# Altium schematic sheet Node Controller v2.SchDoc
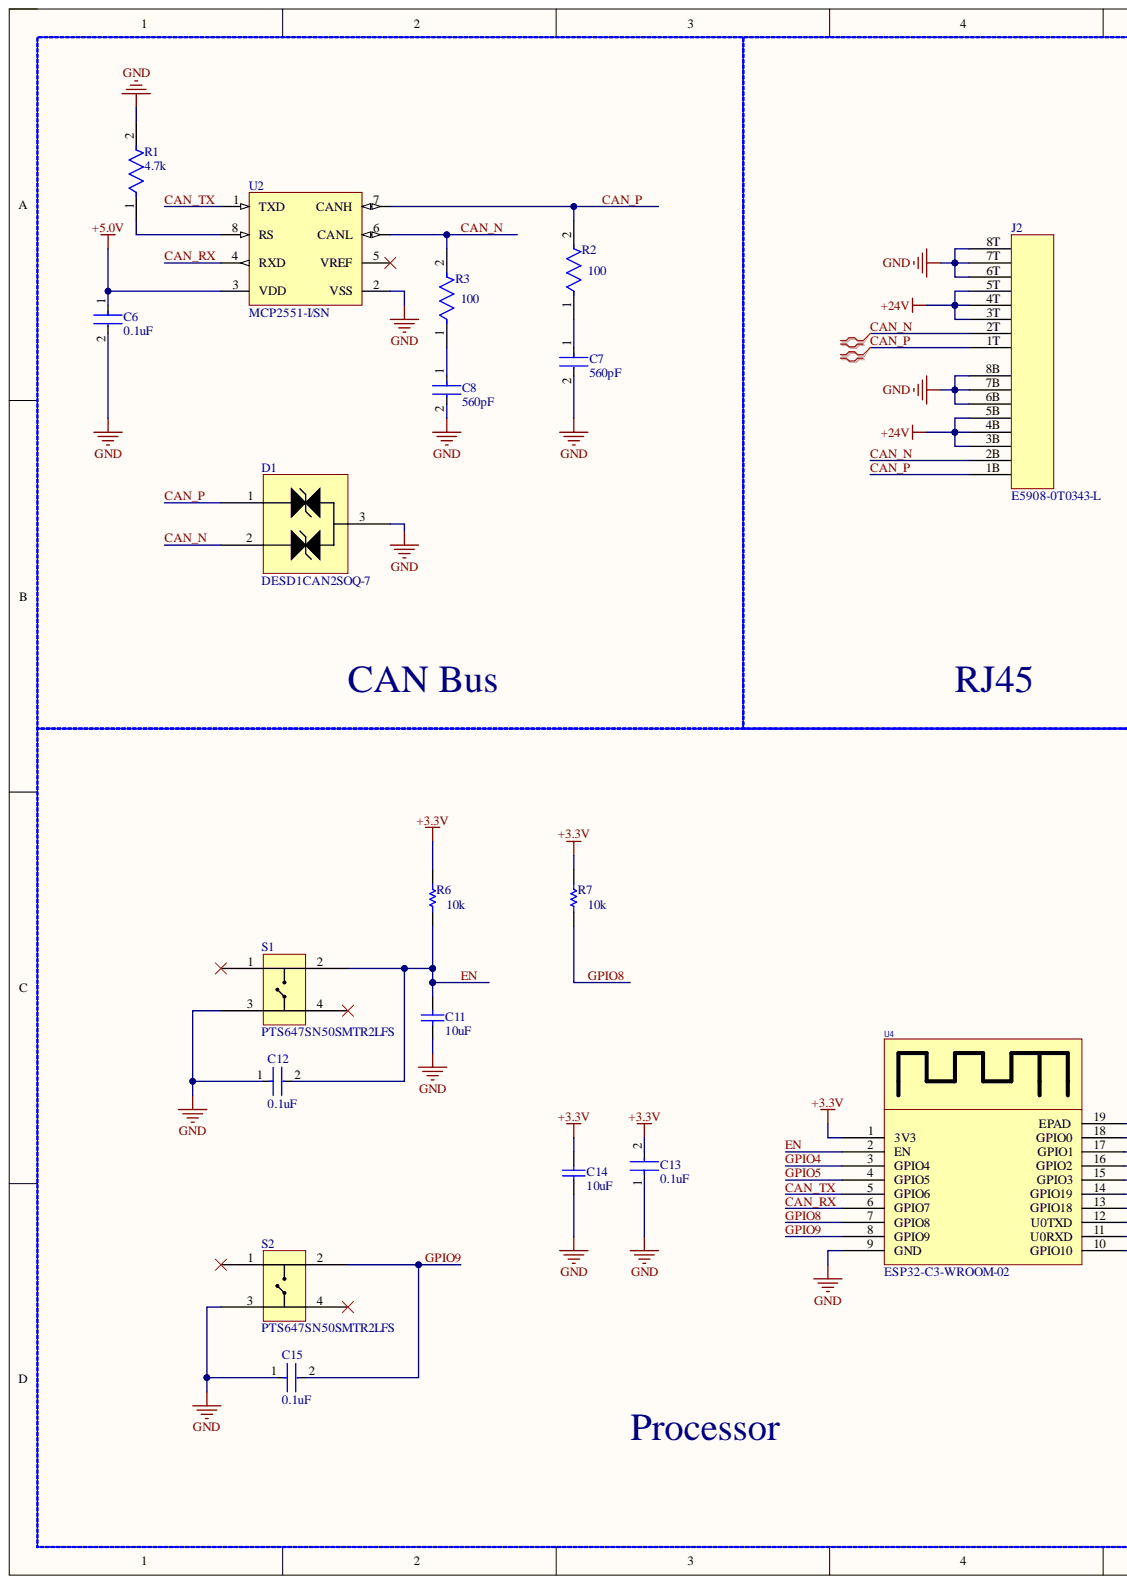
[diagram p0: Node Controller v2.SchDoc, left]
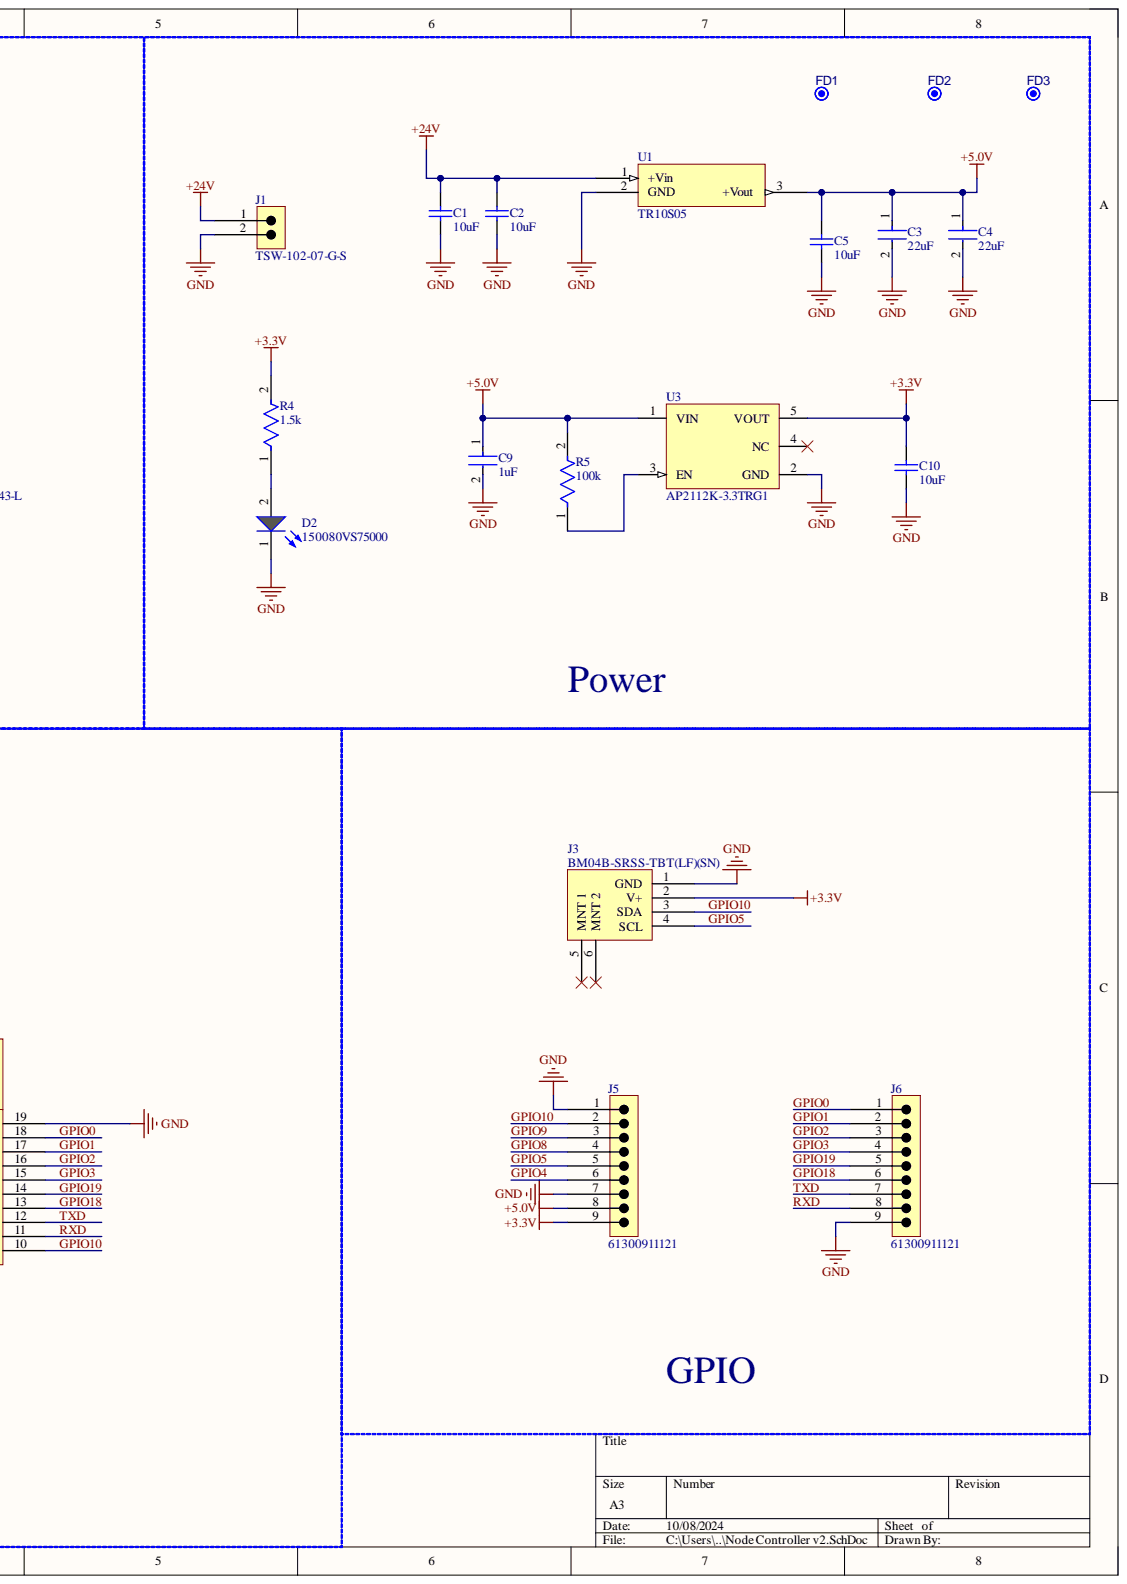
[diagram p1: Node Controller v2.SchDoc, right]

## Parts
C1  10uF  [CAPC2013X145X50ML20T25]
C2  10uF  [CAPC2013X145X50ML20T25]
C3  22uF  [FP-GMC21R-IPC_B]
C4  22uF  [FP-GMC21R-IPC_B]
C5  10uF  [CAPC2013X145X50ML20T25]
C6  0.1uF  [FP-CC0603-DA-IPC_A]
C7  560pF  [FP-CC0805-0_85-IPC_A]
C8  560pF  [FP-CC0805-0_85-IPC_A]
C9  1uF  [FP-CL21-IPC_A]
C10  10uF  [CAPC2013X145X50ML20T25]
C11  10uF  [CAPC2013X145X50ML20T25]
C12  0.1uF  [FP-CC0603-DA-IPC_A]
C13  0.1uF  [FP-CC0603-DA-IPC_A]
C14  10uF  [CAPC2013X145X50ML20T25]
C15  0.1uF  [FP-CC0603-DA-IPC_A]
D1  DESD1CAN2SOQ-7  [SOT95P245X110-3N]
D2  150080VS75000  [WL-SMCW_0805_150080xx75000]
FD1  JLC FID1X2R  [FID1X2R]  (value not drawn)  (no BOM)
FD2  JLC FID1X2R  [FID1X2R]  (value not drawn)  (no BOM)
FD3  JLC FID1X2R  [FID1X2R]  (value not drawn)  (no BOM)
J1  TSW-102-07-G-S  [FP-TSW-102-07-G-S-MFG]
J2  E5908-0T0343-L  [E5908-0T0343-L-Footprint-1]
J3  BM04B-SRSS-TBT(LF)(SN)  [FP-BM04B-SRSS-TBT_LF_SN-MFG]
J5  61300911121  [61300911121]
J6  61300911121  [61300911121]
R1  4.7k  [FP-RT0805-IPC_A]
R2  100  [FP-RT0805-0_6-IPC_A]
R3  100  [FP-RT0805-0_6-IPC_A]
R4  1.5k  [FP-RT0805-IPC_A]
R5  100k  [FP-RT0805-IPC_A]
R6  10k  [RESC2013X60X35ML10T20]
R7  10k  [RESC2013X60X35ML10T20]
S1  PTS647SN50SMTR2LFS  [PTS647SN50SMTR2LFS-Footprint-1]
S2  PTS647SN50SMTR2LFS  [PTS647SN50SMTR2LFS-Footprint-1]
U1  TR10S05  [TR10S05-Footprint-1]
U2  MCP2551-I/SN  [MCHP-SN8_V]
U3  AP2112K-3.3TRG1  [FP-SOT25-IPC_B]
U4  ESP32-C3-WROOM-02  [ESP32-C3-WROOM-02]

## Nets
+3.3V: C10.2 C13.2 C14.2 J3.2(V+) J5.9 R4.2 R6.2 R7.2 U3.5(VOUT) U4.1(3V3)
+5.0V: C3.1 C4.1 C5.2 C6.1 C9.1 J5.8 R5.2 U1.3 U2.3(VDD) U3.1(VIN)
+24V: C1.2 C2.2 J1.1 J2.3B J2.3T J2.4B J2.4T J2.5B J2.5T U1.1
GND: C1.1 C2.1 C3.2 C4.2 C5.1 C6.2 C7.2 C8.2 C9.2 C10.1 C11.1 C12.1 C13.1 C14.1 C15.1 D1.3 D2.1 J1.2 J2.6B J2.6T J2.7B J2.7T J2.8B J2.8T J3.1(GND) J5.1 J5.7 J6.9 R1.2 S1.3 S2.3 U1.2 U2.2(VSS) U3.2(GND) U4.9(GND) U4.19(EPAD)
CAN_N: D1.2 J2.2B J2.2T R3.2 U2.6(CANL)
CAN_P: D1.1 J2.1B J2.1T R2.2 U2.7(CANH)
CAN_RX: U2.4(RXD) U4.6(GPIO7)
CAN_TX: U2.1(TXD) U4.5(GPIO6)
EN: C11.2 C12.2 R6.1 S1.2 U4.2(EN)
GPIO0: J6.1 U4.18(GPIO0)
GPIO1: J6.2 U4.17(GPIO1)
GPIO2: J6.3 U4.16(GPIO2)
GPIO3: J6.4 U4.15(GPIO3)
GPIO4: J5.6 U4.3(GPIO4)
GPIO5: J3.4(SCL) J5.5 U4.4(GPIO5)
GPIO8: J5.4 R7.1 U4.7(GPIO8)
GPIO9: C15.2 J5.3 S2.2 U4.8(GPIO9)
GPIO10: J3.3(SDA) J5.2 U4.10(GPIO10)
GPIO18: J6.6 U4.13(GPIO18)
GPIO19: J6.5 U4.14(GPIO19)
RXD: J6.8 U4.11(U0RXD)
TXD: J6.7 U4.12(U0TXD)
NetC7_1: C7.1 R2.1
NetC8_1: C8.1 R3.1
NetD2_2: D2.2 R4.1
NetR1_1: R1.1 U2.8(RS)
NetR5_1: R5.1 U3.3(EN)

Not connected: J3 5(MNT_1) 6(MNT_2); S1 1 4; S2 1 4; U2 5(VREF); U3 4(NC)

## Library data of the parts
C1 C2 C5 C10 C11 C14 = CMP-00010-1: Ceramic Capacitor, Multilayer, Ceramic, 10V, 80% +Tol, 20% -Tol, Y5V, -82/+22% TC, 10uF, Surface Mount, 0805; Multilayer: Yes; Capacitor Type: Ceramic; Case/Package: 0805; Component Kind: Standard; Dielectric Material: Ceramic; Height: 1.25mm; JESD-609 Code: e3; Length: 2mm; Library Name: C0514760 C0514760; Max Operating Temperature: 85°C; Min Operating Temperature: -30°C; Mounting Technology: Surface Mount; Package Shape: Rectangular; Package Style: SMTMeter; Packaging: Tape and Reel; Pins: 2; Rated DC Voltage (URdc): 10V; Reach SVHC Compliant: Yes; RoHS Compliant: Yes; Temperature Characteristics Code: Y5V; Terminal Finish: Nickel; Termination: Wraparound; Tolerance  (fill in as +-): 20%; Tolerance (fill in as +-): 80%; Value: 10uF; Width: 1.25mm
C3 C4 = CMP-00027-1: Ceramic Capacitor, Multilayer, Ceramic, 35V, 20% +Tol, 20% -Tol, X5R, 15% TC, 22uF, Surface Mount, 0805; Component Kind: Standard; Library Name: C0514760 C0514760
C6 C12 C13 C15 = CMP-00002-1: Ceramic Capacitor, Multilayer, Ceramic, 50V, 10% +Tol, 10% -Tol, X7R, 15% TC, 0.1uF, Surface Mount, 0603; Multilayer: Yes; Value: 100nF; Capacitor Type: Ceramic; Case/Package: 0603; Component Kind: Standard; Dielectric Material: Ceramic; Height: 0.8mm; JESD-609 Code: e3; Length: 1.6mm; Library Name: C0514760 C0514760; Max Operating Temperature: 125°C; Min Operating Temperature: -55°C; Mounting Technology: Surface Mount; Package Shape: Rectangular; Package Style: SMTMeter; Packaging: Tape and Reel; Pins: 2; Rated DC Voltage (URdc): 50V; Reach SVHC Compliant: Yes; RoHS Compliant: Yes; Temperature Characteristics Code: X7R; Terminal Finish: Nickel; Termination: Wraparound; Tolerance  (fill in as +-): 10%; Tolerance (fill in as +-): 10%; Width: 0.8mm
C7 C8 = CMP-00033-1: Ceramic Capacitor, Multilayer, Ceramic, 50V, 10% +Tol, 10% -Tol, X7R, 15% TC, 0.00056uF, Surface Mount, 0805; Multilayer: Yes; Capacitor Type: Ceramic; Case/Package: 0805; Component Kind: Standard; Dielectric Material: Ceramic; JESD-609 Code: e3; Library Name: C0514760 C0514760; Max Operating Temperature: 125°C; Min Operating Temperature: -55°C; Mounting Technology: Surface Mount; Package Shape: Rectangular; Packaging: Tape and Reel; Pins: 2; Rated DC Voltage (URdc): 50V; Reach SVHC Compliant: Yes; RoHS Compliant: Yes; Temperature Characteristics Code: X7R; Terminal Finish: Nickel; Termination: Wraparound; Tolerance  (fill in as +-): 10%; Tolerance (fill in as +-): 10%; Value: 560pF
C9 = CMP-00019-1: Ceramic Capacitor, Multilayer, Ceramic, 50V, 10% +Tol, 10% -Tol, X7R, 15% TC, 1uF, Surface Mount, 0805; Multilayer: Yes; Value: 1µF; Capacitor Type: Ceramic; Case/Package: 0805; Component Kind: Standard; Dielectric Material: Ceramic; Height: 1.35mm; JESD-609 Code: e3; Length: 2mm; Library Name: C0514760 C0514760; Max Operating Temperature: 125°C; Min Operating Temperature: -55°C; Mounting Technology: Surface Mount; Package Shape: Rectangular; Package Style: SMTMeter; Packaging: Tape and Reel; Pins: 2; Rated DC Voltage (URdc): 50V; Reach SVHC Compliant: Yes; RoHS Compliant: Yes; Temperature Characteristics Code: X7R; Terminal Finish: Nickel; Termination: Wraparound; Tolerance  (fill in as +-): 10%; Tolerance (fill in as +-): 10%; Width: 1.25mm
D1 = CMP-00024-2: Trans Voltage Suppressor Diode, 200W, 24V V(RWM), Bidirectional, 2 Element, Silicon; Additional Feature: HIGH RELIABILITY; Max Breakdown Voltage: 30.3V; Max Power Dissipation: 300mW; Min Breakdown Voltage: 25.4V; Number of Elements: 2; Package Body Material: Plastic; Reference Standard: AEC-Q101; Time @ Peak Reflow Temperature-Max (s): 30s; Component Kind: Standard; Diode Element Material: SILICON; Diode Type: TRANS VOLTAGE SUPPRESSOR; JESD-30 Code: R-PDSO-G3; JESD-609 Code: e3; Library Name: C0514760 C0514760; Max Operating Temperature: 150°C; Min Operating Temperature: -65°C; Moisture Sensitivity Level: 1; Non-rep Peak Rev Power Dis-Max: 200W; Package Shape: Rectangular; Package Style: SMALL OUTLINEMeter; Peak Reflow Temperature (Cel): 260°C; Pins: 3; Polarity: Bidirectional; Reach SVHC Compliant: Yes; Rep Pk Reverse Voltage-Max: 24V; RoHS Compliant: Yes; Surface Mount: Yes; Technology: AVALANCHE; Terminal Finish: Tin; Terminal Form: Gull Wing; Terminal Position: DUAL
D2 = CMP-00021-1: Single Color LED, Bright Green, Water Clear, 1.4mm; Channels: 1; Forward Current: 30mA; Luminous Intensity-Nom: 40mcd; Overall Height: 0.7mm; Shape: Rectangular; Viewing Angle: 140°; Color: Green; Component Kind: Standard; Lens Style: WATER CLEAR; Library Name: C0514760 C0514760; Max Operating Temperature: 85°C; Min Operating Temperature: -40°C; Mounting Technology: Surface Mount; Optoelectronic Device Type: SINGLE COLOR LED; Packaging: Tape and Reel; Pins: 2; Reach SVHC Compliant: Yes; RoHS Compliant: Yes; Size: 1.4mm; Terminal Pitch: 2.1mm
FD1-FD3 = CMP-00028-00011-4: Fiducial - Round 1x2mm; Library Name: C0514760 C0514760; Pins: 0
J1 = CMP-00020-2: Board Connector, 2 Contact(s), 1 Row(s), Male, Straight, 0.1 inch Pitch, Solder Terminal, Black Insulator; Additional Feature: E.L.P.; Body/Shell Style: RECEPTACLE; Contact Pattern: RECTANGULAR; Contact Style: SQ PIN-SKT; DIN Conformance: No; Mating Information: MULTIPLE MATING PARTS AVAILABLE; Number Of Connectors: 1; Number of Contacts: 2; Option: General Purpose; Plating Thickness: 10uin; Reference Standard: UL; Reliability: COMMERCIAL; Body Breadth: 0.1inch; Body Depth: 0.1inch; Component Kind: Standard; Connector Type: BOARD CONNECTOR; Contact Finish - Mating: GOLD (10) OVER NICKEL (50); Contact Finish - Termination: Gold (Au) - with Nickel (Ni) barrier; Contact Gender: Male; Contact Material: Bronze; Filter Feature: No; Flammability Rating: UL94 V-0; IEC Conformance: No; Insulation Color: Black; Insulation Material: Glass; JESD-609 Code: e4; Library Name: C0514760 C0514760; Mating Contact Pitch: 0.1inch; MIL Conformance: No; Mixed Contacts: No; Mounting Style: STRAIGHT; Mounting Technology: Board; Number Of PCB Rows: 1; Number of Rows Loaded: 1; PCB Contact Pattern: RECTANGULAR; Reach SVHC Compliant: Yes; RoHS Compliant: Yes; Terminal Length: 0.1inch; Terminal Pitch: 2.54mm; Termination: Solder
J2 = CMP-002-00077-7: Telecom and Datacom Connector, 16 Contact(s), Female, Right Angle, Solder Terminal, Jack; Manufacturer Series: E5908; DIN Conformance: No; Number of Contacts: 16; Option: General Purpose; Body/Shell Style: JACK; Component Kind: Standard; Connector Type: TELECOM AND DATACOM CONNECTOR; Contact Finish - Mating: GOLD (15) OVER NICKEL; Contact Finish - Termination: GOLD (15) OVER NICKEL; Contact Gender: Female; Empty Shell: No; Filter Feature: No; Flammability Rating: UL94 V-0; IEC Conformance: No; Insulation Material: Glass; JESD-609 Code: e4; Library Name: C0514760 C0514760; MIL Conformance: No; Mixed Contacts: No; Mounting Style: RIGHT ANGLE; Mounting Technology: Board; Reach SVHC Compliant: Yes; RoHS Compliant: Yes; Shell Material: Glass; Termination: Solder
J3 = CMP-002-00076-4: 1.0mm Pitch, Ultra-Compact, Low Profile Design, Interchangeable Shrouded Header; Component Kind: Standard; Library Name: C0514760 C0514760
J5 J6 = CMP-00023-4: Board Connector, 9 Contact(s), 1 Row(s), Male, Straight, 0.1 inch Pitch, Solder Terminal, Locking, Black Insulator, Plug; Body Length: 0.9inch; Contact Pattern: RECTANGULAR; Contact Resistance: 20mR; Contact Style: SQ PIN-SKT; Dielectric Withstanding Voltage (AC): 500V; Insulation Resistance: 1GR; Number Of Connectors: 1; Number of Contacts: 9; Rated Current (Signal): 3A; Reference Standard: UL; Reliability: COMMERCIAL; Body Breadth: 0.1inch; Body Depth: 0.1inch; Body/Shell Style: PLUG; Component Kind: Standard; Connector Type: BOARD CONNECTOR; Contact Finish - Mating: GOLD; Contact Finish - Termination: Gold (Au) - with Nickel (Ni) barrier; Contact Gender: Male; Contact Material: Copper Alloy; Insulation Color: Black; Insulation Material: Polybutylene; JESD-609 Code: e4; Library Name: C0514760 C0514760; Mating Contact Pitch: 0.1inch; Max Operating Temperature: 125°C; Min Operating Temperature: -40°C; Mounting Option 1: LOCKING; Mounting Style: STRAIGHT; Mounting Technology: Board; Number of Rows Loaded: 1; PCB Contact Pattern: RECTANGULAR; Reach SVHC Compliant: Yes; RoHS Compliant: Yes; Terminal Length: 0.118inch; Terminal Pitch: 2.54mm; Termination: Solder
R1 = CMP-00015-1: Fixed Resistor, Thin Film, 0.125W, 4700ohm, 150V, 1% +/-Tol, 50ppm/Cel, Surface Mount, 0805; Additional Feature: HIGH PRECISION; Package Height: 0.5mm; Package Length: 2mm; Package Width: 1.25mm; Power: 125mW; Rated Temperature: 70°C; Temperature Coefficient: 50ppm/°C; Value: 4.7kR; Case/Package: 0805; Component Kind: Standard; Construction: Chip; Library Name: C0514760 C0514760; Max Operating Temperature: 155°C; Min Operating Temperature: -55°C; Mounting Technology: Surface Mount; Package Style: SMTMeter; Packaging: Tape and Reel; Pins: 2; Reach SVHC Compliant: Yes; Resistor Type: FIXED RESISTOR; RoHS Compliant: Yes; Technology: THIN FILM; Termination: Wraparound; Tolerance: 1%; Working Voltage: 150V
R2 R3 = CMP-00016-1: Fixed Resistor, Thin Film, 0.125W, 100ohm, 150V, 0.1% +/-Tol, 25ppm/Cel, Surface Mount, 0805; Additional Feature: HIGH PRECISION; Package Height: 0.5mm; Package Length: 2mm; Package Width: 1.25mm; Power: 125mW; Rated Temperature: 70°C; Temperature Coefficient: 25ppm/°C; Value: 100R; Case/Package: 0805; Component Kind: Standard; Construction: Chip; Library Name: C0514760 C0514760; Max Operating Temperature: 155°C; Min Operating Temperature: -55°C; Mounting Technology: Surface Mount; Package Style: SMTMeter; Packaging: Tape and Reel; Pins: 2; Reach SVHC Compliant: Yes; Resistor Type: FIXED RESISTOR; RoHS Compliant: Yes; Technology: THIN FILM; Termination: Wraparound; Tolerance: 0.1%; Working Voltage: 150V
R4 = CMP-00013-1: Fixed Resistor, Thin Film, 0.125W, 1500ohm, 150V, 1% +/-Tol, 50ppm/Cel, Surface Mount, 0805; Additional Feature: HIGH PRECISION; Package Height: 0.5mm; Package Length: 2mm; Package Width: 1.25mm; Power: 125mW; Rated Temperature: 70°C; Temperature Coefficient: 50ppm/°C; Value: 1.5kR; Case/Package: 0805; Component Kind: Standard; Construction: Chip; Library Name: C0514760 C0514760; Max Operating Temperature: 155°C; Min Operating Temperature: -55°C; Mounting Technology: Surface Mount; Package Style: SMTMeter; Packaging: Tape and Reel; Pins: 2; Reach SVHC Compliant: Yes; Resistor Type: FIXED RESISTOR; RoHS Compliant: Yes; Technology: THIN FILM; Termination: Wraparound; Tolerance: 1%; Working Voltage: 150V
R5 = CMP-00014-1: Fixed Resistor, Thin Film, 0.125W, 100000ohm, 150V, 1% +/-Tol, 50ppm/Cel, Surface Mount, 0805; Additional Feature: HIGH PRECISION; Package Height: 0.5mm; Package Length: 2mm; Package Width: 1.25mm; Power: 125mW; Rated Temperature: 70°C; Temperature Coefficient: 50ppm/°C; Value: 100kR; Case/Package: 0805; Component Kind: Standard; Construction: Chip; Library Name: C0514760 C0514760; Max Operating Temperature: 155°C; Min Operating Temperature: -55°C; Mounting Technology: Surface Mount; Package Style: SMTMeter; Packaging: Tape and Reel; Pins: 2; Reach SVHC Compliant: Yes; Resistor Type: FIXED RESISTOR; RoHS Compliant: Yes; Technology: THIN FILM; Termination: Wraparound; Tolerance: 1%; Working Voltage: 150V
R6 R7 = CMP-00012-1: Fixed Resistor, Metal Glaze/thick Film, 0.125W, 10000ohm, 150V, 1% +/-Tol, 100ppm/Cel, Surface Mount, 0805; Additional Feature: LASER TRIMMABLE; Package Height: 0.5mm; Package Length: 2mm; Package Width: 1.25mm; Power: 125mW; Rated Temperature: 70°C; Temperature Coefficient: 100ppm/°C; Value: 10kR; Case/Package: 0805; Component Kind: Standard; Construction: Chip; JESD-609 Code: e3; Library Name: C0514760 C0514760; Max Operating Temperature: 155°C; Min Operating Temperature: -55°C; Mounting Technology: Surface Mount; Package Shape: Rectangular; Package Style: SMTMeter; Packaging: Tape and Reel; Pins: 2; Reach SVHC Compliant: Yes; Resistor Type: FIXED RESISTOR; RoHS Compliant: Yes; Technology: METAL GLAZE/THICK FILM; Terminal Finish: Nickel; Termination: Wraparound; Tolerance: 1%; Working Voltage: 150V
S1 S2 = CMP-011-00013-3: Keypad Switch, 1 Switches, SPST, Momentary-tactile, 0.05A, 12VDC, 1.47N, Solder Terminal, Surface Mount-straight; Component Kind: Standard; Library Name: C0514760 C0514760; RoHS Compliant: Yes
U1 = CMP-019-00050-2: DC-DC Regulated Power Supply Module; Component Kind: Standard; Library Name: C0514760 C0514760; Reach SVHC Compliant: Yes; RoHS Compliant: Yes; Throw Configuration: DC-DC REGULATED POWER SUPPLY MODULE
U2 = CMP-015-00008-2: CAN Transceiver, 1-Trnsvr, PDSO8; Channels: 1; Data Rate: 1000Mbps; Max Supply Current: 75uA; Nominal Supply Voltage: 5V; Package Body Material: Plastic; Package Equivalence Code: SOP8,.25; Qualification Status: Not Qualified; Telecom IC Type: INTERFACE CIRCUIT; Time @ Peak Reflow Temperature-Max (s): 40s; Case/Package: SOP; Component Kind: Standard; Height - Seated (Max): 1.75mm; JESD-30 Code: R-PDSO-G8; JESD-609 Code: e3; Length: 4.9mm; Library Name: C0514760 C0514760; Max Operating Temperature: 85°C; Min Operating Temperature: -40°C; Moisture Sensitivity Level: 1; Number of Transceivers: 1; Package Shape: Rectangular; Package Style: SMALL OUTLINEMeter; Peak Reflow Temperature (Cel): 260°C; Pins: 8; Power Supplies: 5V; Reach SVHC Compliant: Yes; RoHS Compliant: Yes; Surface Mount: Yes; Technology: CMOS; Temperature Grade: INDUSTRIAL; Terminal Finish: Tin; Terminal Form: Gull Wing; Terminal Pitch: 1.27mm; Terminal Position: DUAL; Width: 3.9mm
U3 = CMP-019-00049-4: Fixed Positive LDO Regulator, 3.3V, 0.4V Dropout, CMOS, PDSO5; Max Supply Voltage: 6V; Case/Package: LSSOP; Component Kind: Standard; Library Name: C0514760 C0514760; Max Operating Temperature: 85°C; Min Operating Temperature: -40°C; Pins: 5; RoHS Compliant: Yes
U4 = CMP-005-00015-5: ki_description: ESP32-C3-WROOM-02 is a general-purpose Wi-Fi and Bluetooth LE module. This module features a rich set of peripherals and high performance, which makes it an ideal choice for smart home, industrial automation, health care, consumer electronics, etc.; ki_keywords: esp32-c3 module; Reference: U; Component Kind: Standard; Datasheet: https://www.espressif.com/sites/default/files/documentation/esp32-c3-wroom-02_datasheet_en.pdf; Design Item ID: ESP32-C3-WROOM-02; Library Name: C0514760 C0514760; Source: NodeController.SCHLIB; Value: ESP32-C3-WROOM-02
